# Altium schematic sheet 14_NTC_TEMPSENSOR.SchDoc(14_NTC_TEMPSENSOR)
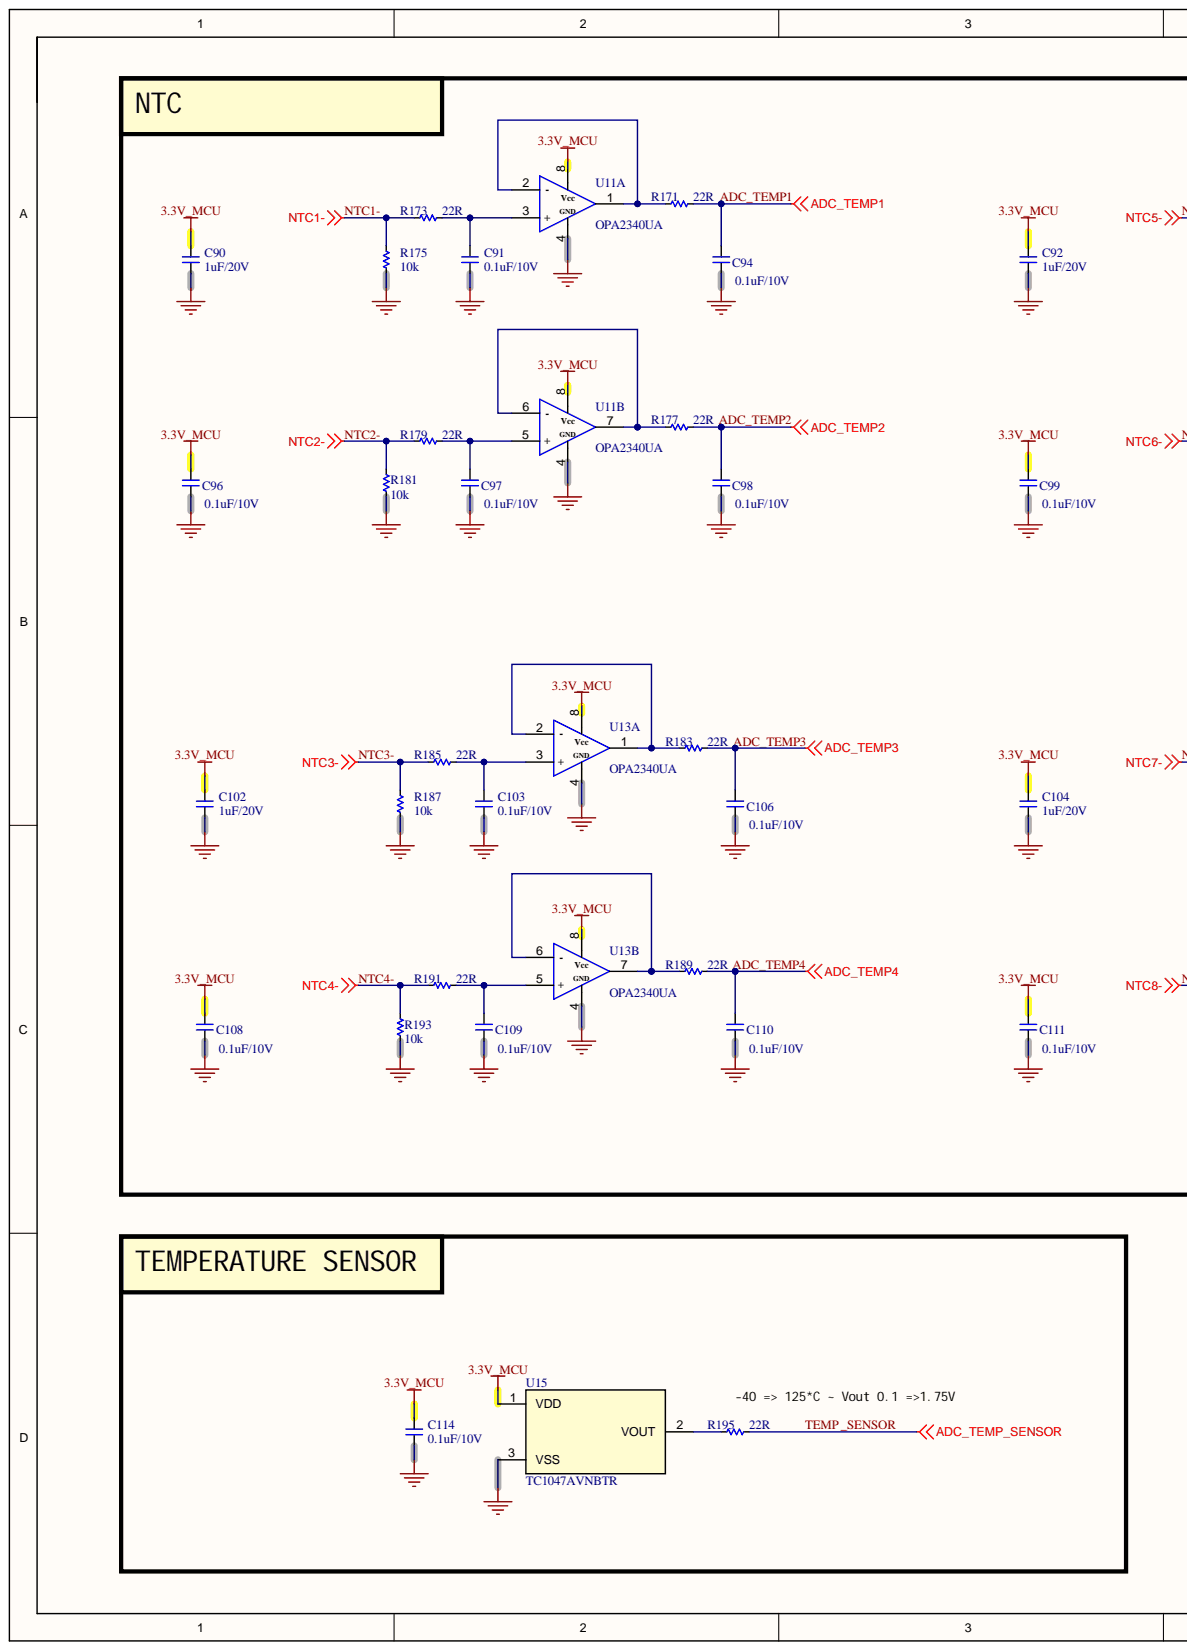
[diagram p0: 14_NTC_TEMPSENSOR.SchDoc, left]
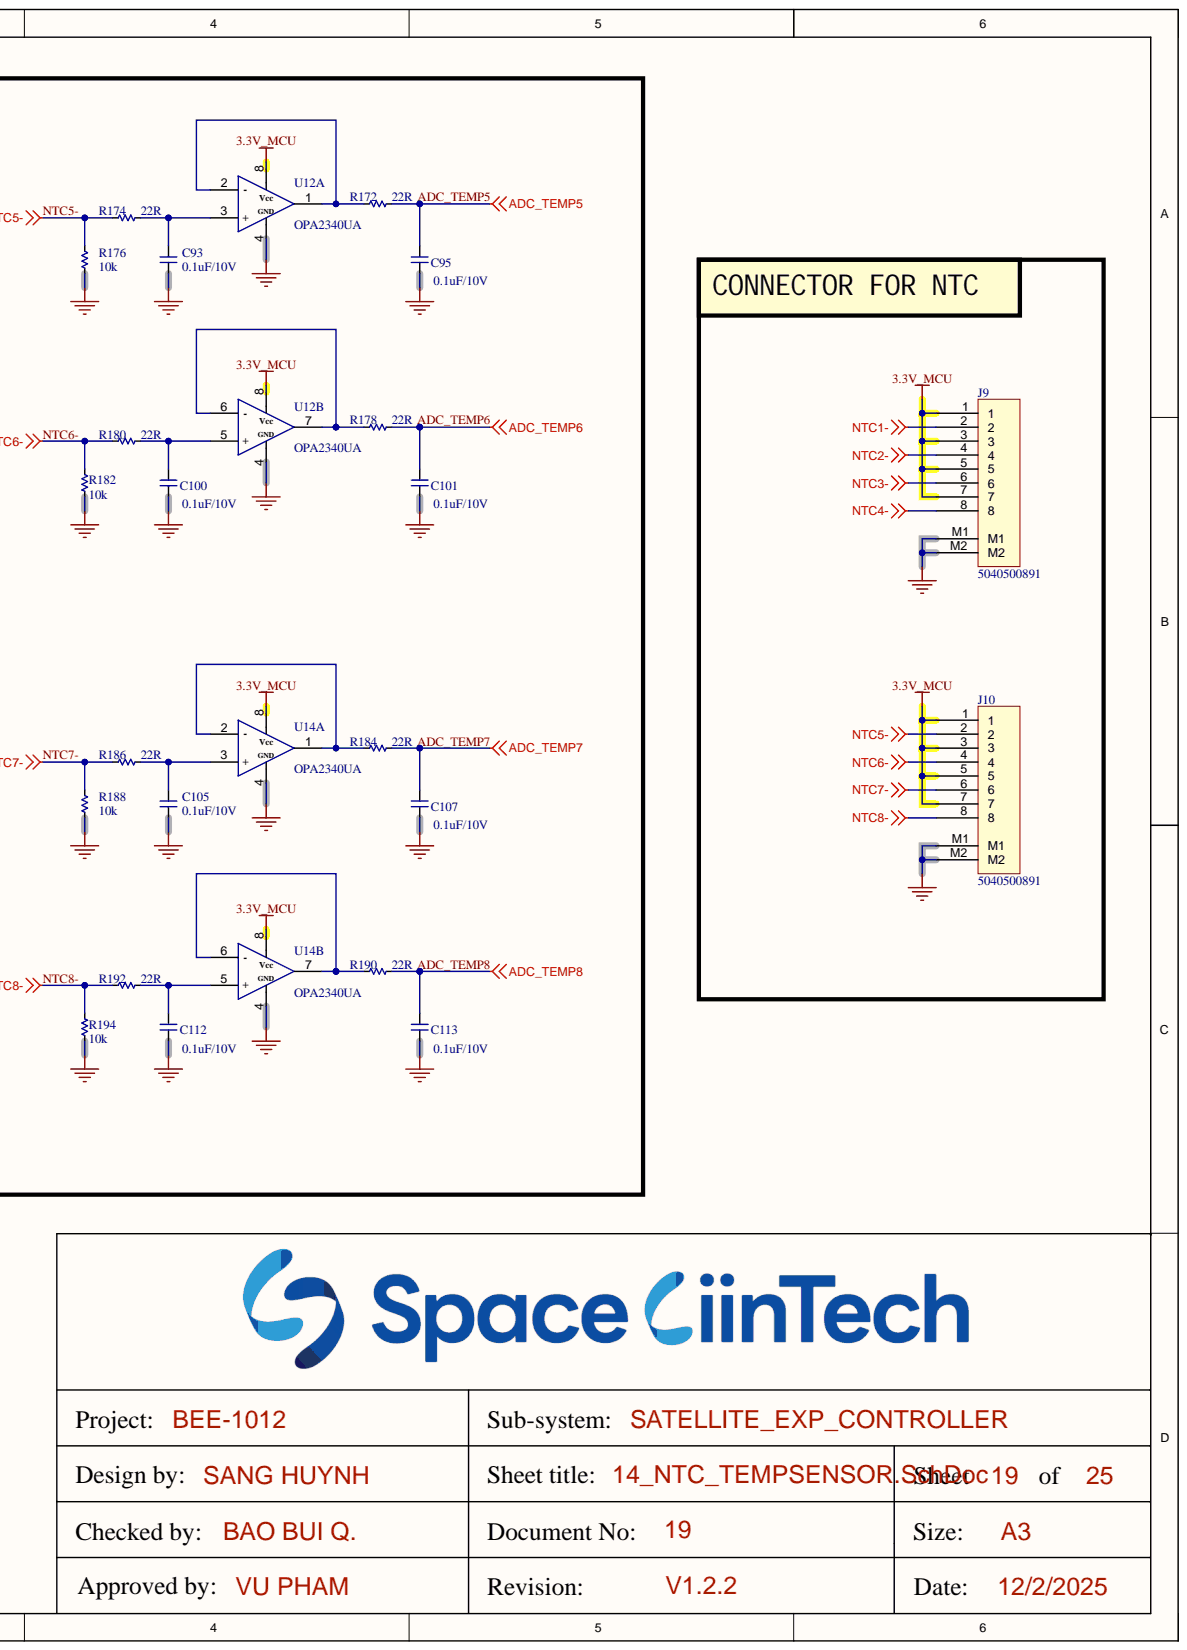
[diagram p1: 14_NTC_TEMPSENSOR.SchDoc, right]

## Parts
C90  1uF/20V  [CAP_C_1005X60]
C91  0.1uF/10V  [CAP_C_1005X60]
C92  1uF/20V  [CAP_C_1005X60]
C93  0.1uF/10V  [CAP_C_1005X60]
C94  0.1uF/10V  [CAP_C_1005X60]
C95  0.1uF/10V  [CAP_C_1005X60]
C96  0.1uF/10V  [CAP_C_1005X60]
C97  0.1uF/10V  [CAP_C_1005X60]
C98  0.1uF/10V  [CAP_C_1005X60]
C99  0.1uF/10V  [CAP_C_1005X60]
C100  0.1uF/10V  [CAP_C_1005X60]
C101  0.1uF/10V  [CAP_C_1005X60]
C102  1uF/20V  [CAP_C_1005X60]
C103  0.1uF/10V  [CAP_C_1005X60]
C104  1uF/20V  [CAP_C_1005X60]
C105  0.1uF/10V  [CAP_C_1005X60]
C106  0.1uF/10V  [CAP_C_1005X60]
C107  0.1uF/10V  [CAP_C_1005X60]
C108  0.1uF/10V  [CAP_C_1005X60]
C109  0.1uF/10V  [CAP_C_1005X60]
C110  0.1uF/10V  [CAP_C_1005X60]
C111  0.1uF/10V  [CAP_C_1005X60]
C112  0.1uF/10V  [CAP_C_1005X60]
C113  0.1uF/10V  [CAP_C_1005X60]
C114  0.1uF/10V  [CAP_C_1005X60]
J9  5040500891  [MOLEX_5040500891]
J10  5040500891  [MOLEX_5040500891]
R171  22R  [RES_C_1005X40]
R172  22R  [RES_C_1005X40]
R173  22R  [RES_C_1005X40]
R174  22R  [RES_C_1005X40]
R175  10k  [RES_C_1005X40]
R176  10k  [RES_C_1005X40]
R177  22R  [RES_C_1005X40]
R178  22R  [RES_C_1005X40]
R179  22R  [RES_C_1005X40]
R180  22R  [RES_C_1005X40]
R181  10k  [RES_C_1005X40]
R182  10k  [RES_C_1005X40]
R183  22R  [RES_C_1005X40]
R184  22R  [RES_C_1005X40]
R185  22R  [RES_C_1005X40]
R186  22R  [RES_C_1005X40]
R187  10k  [RES_C_1005X40]
R188  10k  [RES_C_1005X40]
R189  22R  [RES_C_1005X40]
R190  22R  [RES_C_1005X40]
R191  22R  [RES_C_1005X40]
R192  22R  [RES_C_1005X40]
R193  10k  [RES_C_1005X40]
R194  10k  [RES_C_1005X40]
R195  22R  [RES_C_1005X40]
U11  OPA2340UA  [SOIC127P602X173-8N]  (parts A,B)
U12  OPA2340UA  [SOIC127P602X173-8N]  (parts A,B)
U13  OPA2340UA  [SOIC127P602X173-8N]  (parts A,B)
U14  OPA2340UA  [SOIC127P602X173-8N]  (parts A,B)
U15  TC1047AVNBTR  [SOT95P240X120-3N]

## Nets
3.3V_MCU: C90.2 C92.2 C96.2 C99.2 C102.2 C104.2 C108.2 C111.2 C114.2 J9.1 J9.3 J9.5 J9.7 J10.1 J10.3 J10.5 J10.7 U11.8 U12.8 U13.8 U14.8 U15.1
GND: C90.1 C91.1 C92.1 C93.1 C94.1 C95.1 C96.1 C97.1 C98.1 C99.1 C100.1 C101.1 C102.1 C103.1 C104.1 C105.1 C106.1 C107.1 C108.1 C109.1 C110.1 C111.1 C112.1 C113.1 C114.1 J9.M1 J9.M2 J10.M1 J10.M2 R175.1 R176.1 R181.1 R182.1 R187.1 R188.1 R193.1 R194.1 U11.4 U12.4 U13.4 U14.4 U15.3
ADC_TEMP1: C94.2 R171.2
ADC_TEMP2: C98.2 R177.2
ADC_TEMP3: C106.2 R183.2
ADC_TEMP4: C110.2 R189.2
ADC_TEMP5: C95.2 R172.2
ADC_TEMP6: C101.2 R178.2
ADC_TEMP7: C107.2 R184.2
ADC_TEMP8: C113.2 R190.2
NTC1-: J9.2 R173.1 R175.2
NTC2-: J9.4 R179.1 R181.2
NTC3-: J9.6 R185.1 R187.2
NTC4-: J9.8 R191.1 R193.2
NTC5-: J10.2 R174.1 R176.2
NTC6-: J10.4 R180.1 R182.2
NTC7-: J10.6 R186.1 R188.2
NTC8-: J10.8 R192.1 R194.2
TEMP _SENSOR: R195.2
NetC91_2: C91.2 R173.2 U11.3(+)
NetC93_2: C93.2 R174.2 U12.3(+)
NetC97_2: C97.2 R179.2 U11.5(+)
NetC100_2: C100.2 R180.2 U12.5(+)
NetC103_2: C103.2 R185.2 U13.3(+)
NetC105_2: C105.2 R186.2 U14.3(+)
NetC109_2: C109.2 R191.2 U13.5(+)
NetC112_2: C112.2 R192.2 U14.5(+)
NetR171_1: R171.1 U11.1 U11.2(-)
NetR172_1: R172.1 U12.1 U12.2(-)
NetR177_1: R177.1 U11.6(-) U11.7
NetR178_1: R178.1 U12.6(-) U12.7
NetR183_1: R183.1 U13.1 U13.2(-)
NetR184_1: R184.1 U14.1 U14.2(-)
NetR189_1: R189.1 U13.6(-) U13.7
NetR190_1: R190.1 U14.6(-) U14.7
NetR195_1: R195.1 U15.2

## Library data of the parts
C90-C114 = _CAP_C_2: CAP CHIP; Component Kind: Standard; Library Name: LABLIB.SchLib
J9 J10 = Header 8x1_2M: Component Kind: Standard; Library Name: LABLIB.SchLib
R171-R195 = _RES_B_2: RES; Component Kind: Standard; Library Name: LABLIB.SchLib
U15 = IC_SENSOR_TC1047AVNBTR: Temperature Sensor Analog, Local -40°C ~ 125°C 10mV/°C SOT-23-3; Component Kind: Standard; Library Name: LABLIB.SchLib
U11-U14 = OPA2340UA: Component Kind: Standard; Library Name: EXP_HW_V3.SchLib
